# Altium schematic sheet UNO.SchDoc(UNO)
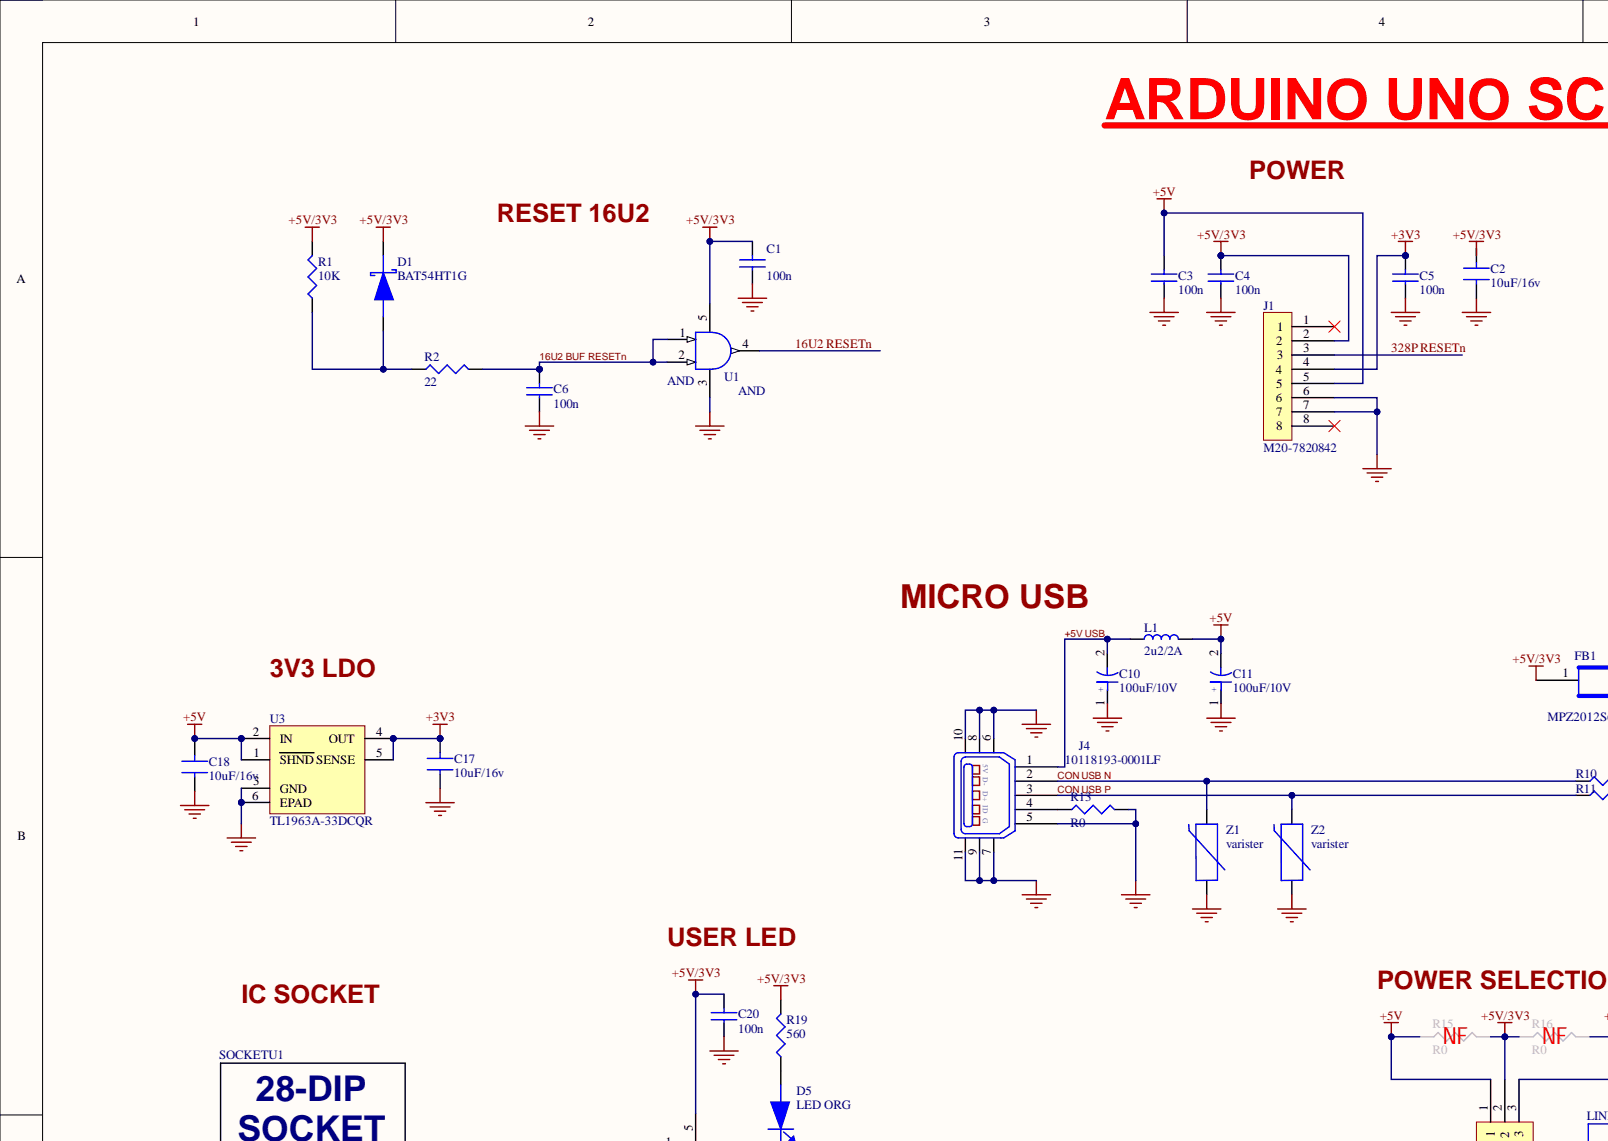
[diagram p0: UNO.SchDoc, top left]
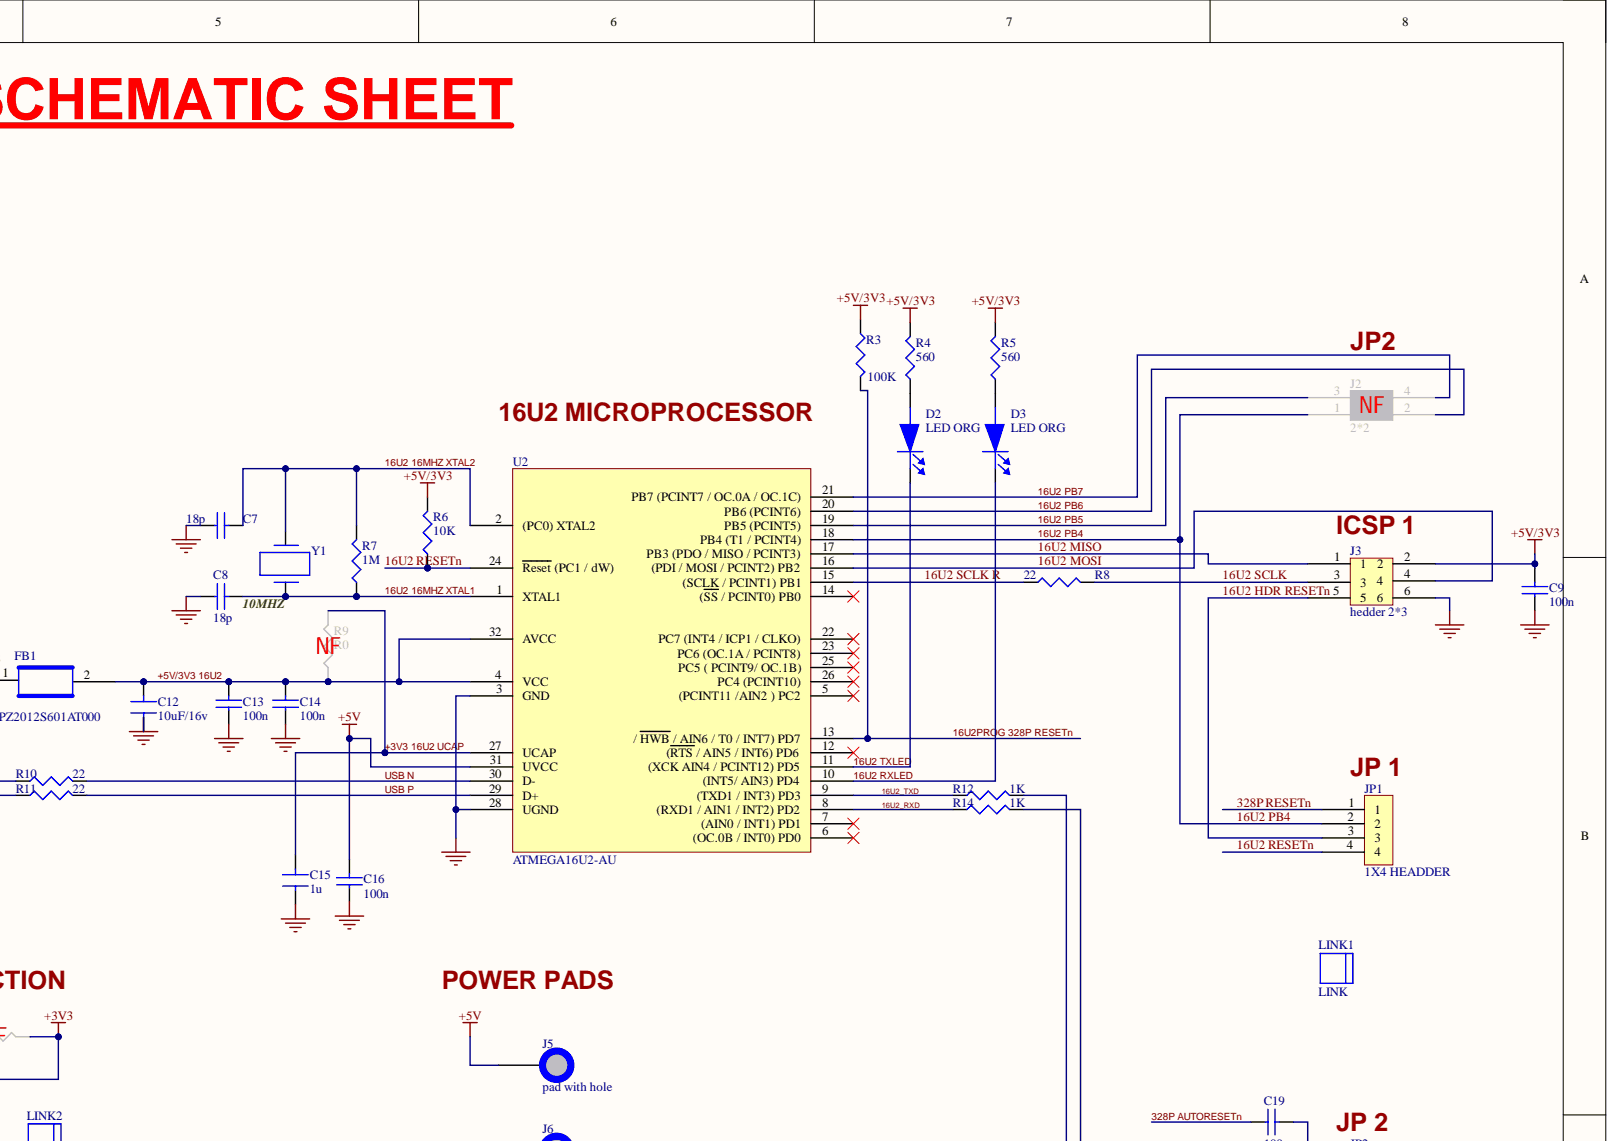
[diagram p1: UNO.SchDoc, top right]
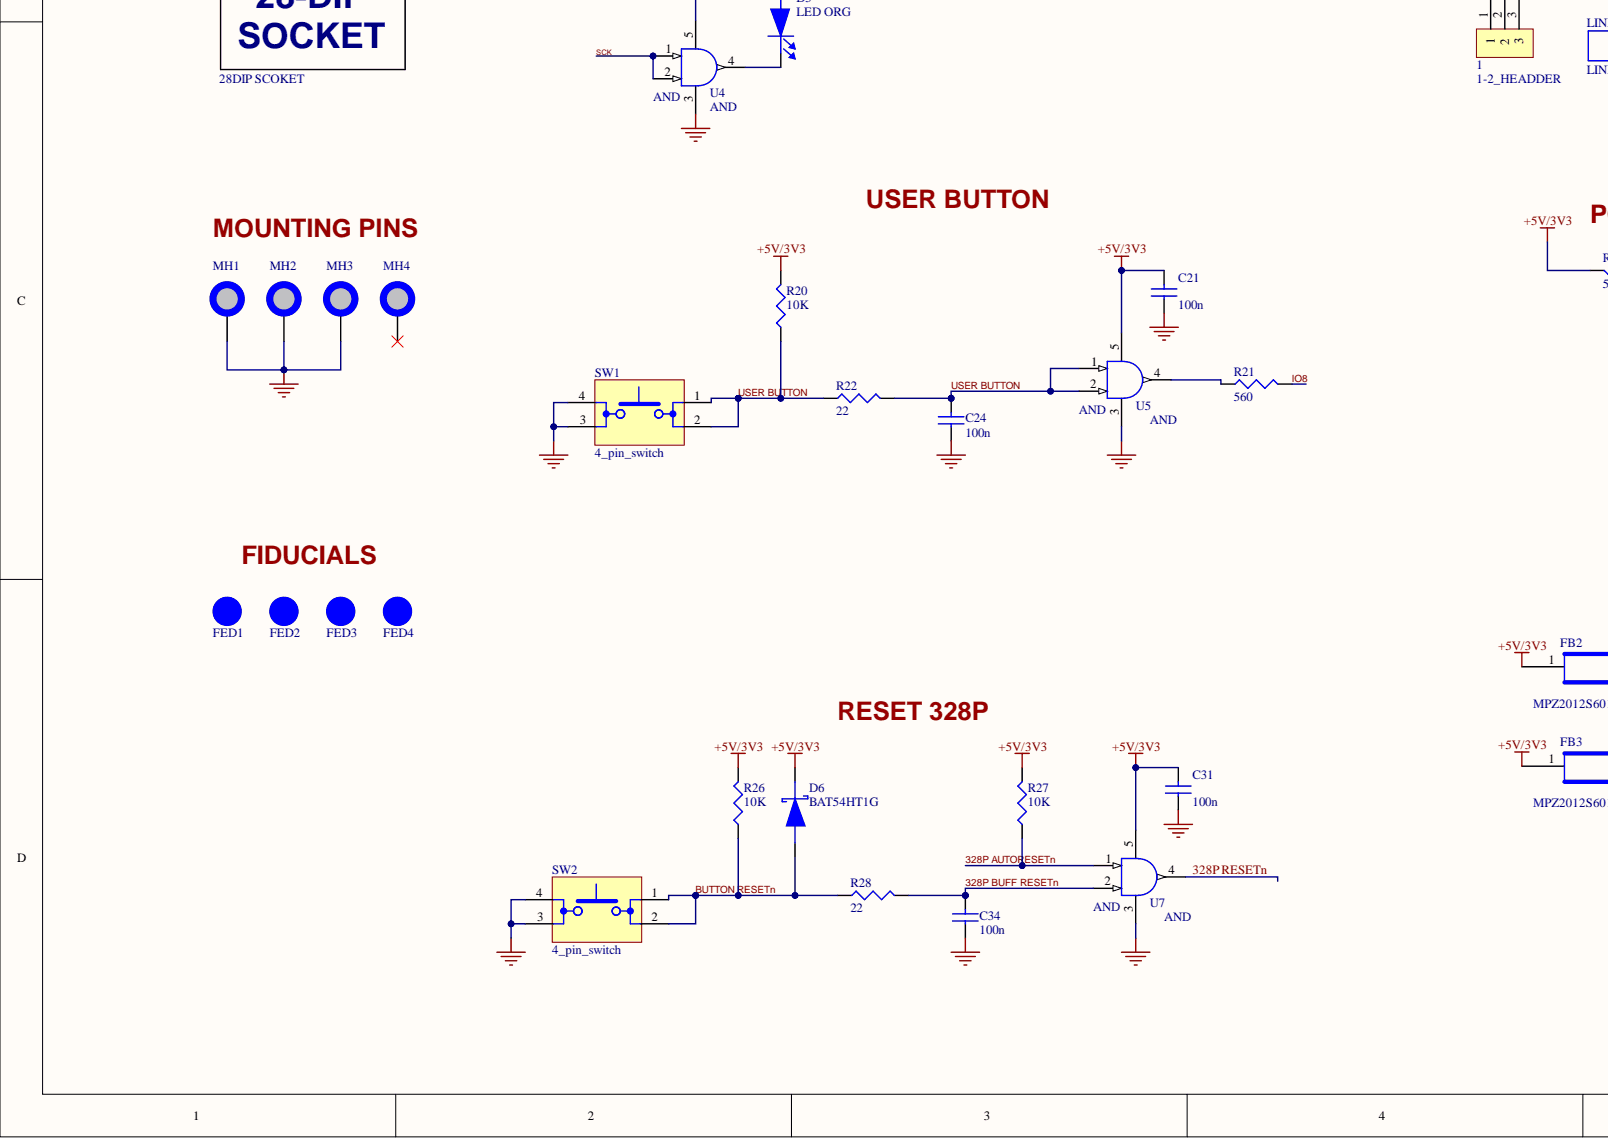
[diagram p2: UNO.SchDoc, bottom left]
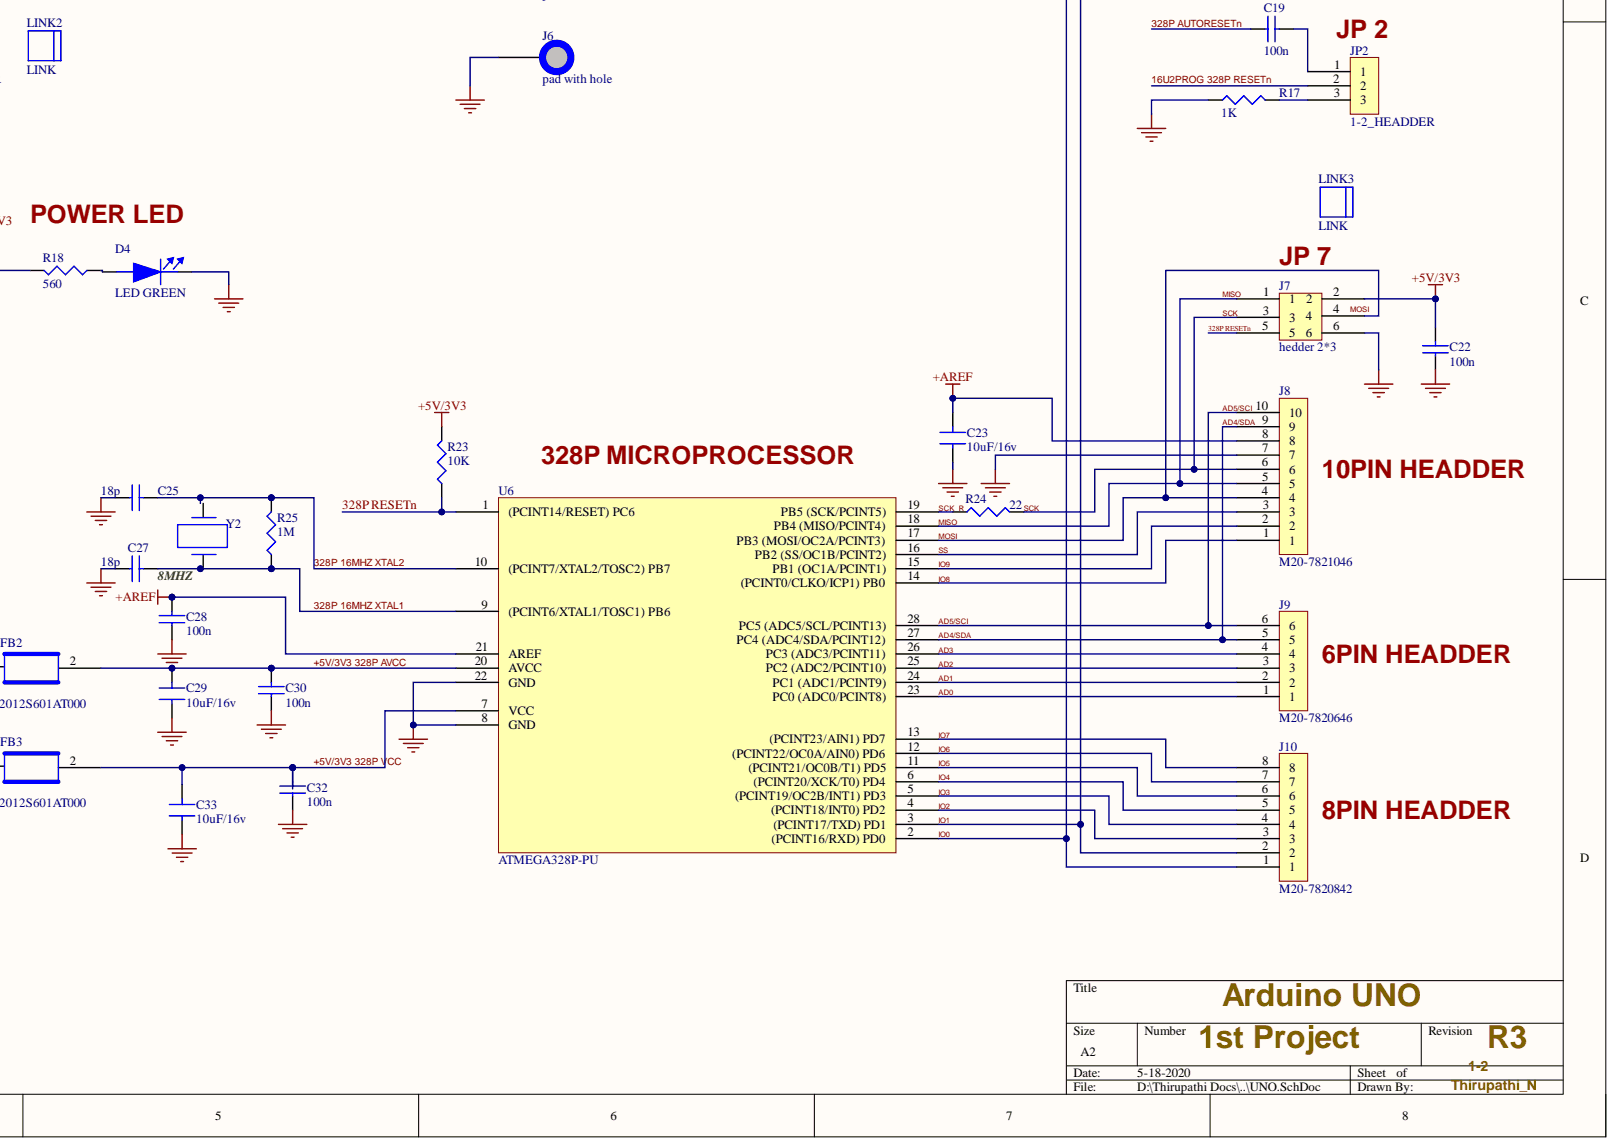
[diagram p3: UNO.SchDoc, bottom right]

## Parts
1  1-2_HEADDER  [hedder_female_3_pins - duplicate]
C1  100n  [C0805]
C2  10uF/16v  [C0805]
C3  100n  [C0805]
C4  100n  [C0805]
C5  100n  [C0805]
C6  100n  [C0805]
C7  18p  [C0805]
C8  18p  [C0805]
C9  100n  [C0805]
C10  100uF/10V  [C ALUMI]
C11  100uF/10V  [C ALUMI]
C12  10uF/16v  [C0805]
C13  100n  [C0805]
C14  100n  [C0805]
C15  1u  [C0805]
C16  100n  [C0805]
C17  10uF/16v  [C0805]
C18  10uF/16v  [C0805]
C19  100n  [C0805]
C20  100n  [C0805]
C21  100n  [C0805]
C22  100n  [C0805]
C23  10uF/16v  [C0805]
C24  100n  [C0805]
C25  18p  [C0805]
C27  18p  [C0805]
C28  100n  [C0805]
C29  10uF/16v  [C0805]
C30  100n  [C0805]
C31  100n  [C0805]
C32  100n  [C0805]
C33  10uF/16v  [C0805]
C34  100n  [C0805]
D1  BAT54HT1G  [diode]
D2  LED ORG  [LED ORG]
D3  LED ORG  [LED ORG]
D4  LED GREEN  [LED ORG]
D5  LED ORG  [LED ORG]
D6  BAT54HT1G  [diode]
FB1  MPZ2012S601AT000  [C0805]
FB2  MPZ2012S601AT000  [C0805]
FB3  MPZ2012S601AT000  [C0805]
FED1  FIDUCIAL 1MM  [FIDICIAL1]  (value not drawn)  (no BOM)
FED2  FIDUCIAL 1MM  [FIDICIAL1]  (value not drawn)  (no BOM)
FED3  FIDUCIAL 1MM  [FIDICIAL1]  (value not drawn)  (no BOM)
FED4  FIDUCIAL 1MM  [FIDICIAL1]  (value not drawn)  (no BOM)
J1  M20-7820842  [hedder_female_8_pins - duplicate]
J2  2*2  [hedder 2*2]
J3  hedder 2*3  [hedder 2*3]
J4  10118193-0001LF  [USB]
J5  pad with hole  [pad with hole]  (no BOM)
J6  pad with hole  [pad with hole]  (no BOM)
J7  hedder 2*3  [hedder 2*3]
J8  M20-7821046  [hedder_female]
J9  M20-7820646  [hedder_female_6_pins]
J10  M20-7820842  [hedder_female_8_pins - duplicate]
JP1  1X4 HEADDER  [hedder_female_4_pins]
JP2  1-2_HEADDER  [hedder_female_3_pins - duplicate]
L1  2u2/2A  [L2u2/2A]
LINK1  LINK  (mechanical)
LINK2  LINK  (mechanical)
LINK3  LINK  (mechanical)
MH1  Mount Holes  [MOUNTING HOLES]  (value not drawn)  (no BOM)
MH2  Mount Holes  [MOUNTING HOLES]  (value not drawn)  (no BOM)
MH3  Mount Holes  [MOUNTING HOLES]  (value not drawn)  (no BOM)
MH4  7.4 MOUNT  [MOUNTING7.4]  (value not drawn)  (no BOM)
R1  10K  [R0805]
R2  22  [R0805]
R3  100K  [R0805]
R4  560  [R0805]
R5  560  [R0805]
R6  10K  [R0805]
R7  1M  [R0805]
R8  22  [R0805]
R9  R0  [R0805]
R10  22  [R0805]
R11  22  [R0805]
R12  1K  [R0805]
R13  R0  [R0805]
R14  1K  [R0805]
R15  R0  [R0805]
R16  R0  [R0805]
R17  1K  [R0805]
R18  560  [R0805]
R19  560  [R0805]
R20  10K  [R0805]
R21  560  [R0805]
R22  22  [R0805]
R23  10K  [R0805]
R24  22  [R0805]
R25  1M  [R0805]
R26  10K  [R0805]
R27  10K  [R0805]
R28  22  [R0805]
SOCKETU1  28DIP SCOKET  [SOCKET]
SW1  4_pin_switch  [4pin_switch]
SW2  4_pin_switch  [4pin_switch]
U1  AND  [AND]
U2  ATMEGA16U2-AU  [TQFP 32]
U3  TL1963A-33DCQR  [SOP10]
U4  AND  [AND]
U5  AND  [AND]
U6  ATMEGA328P-PU  [U?]
U7  AND  [AND]
Y1
Y1  10MHZ  [Crystal]
Y2
Y2  8MHZ  [Crystal]
Z1  varister  [R0603]
Z2  varister  [R0603]

## Nets
+3V3: 1.3 C5.2 C17.2 J1.4 R16.2 U3.4(OUT) U3.5(SENSE)
+5V: 1.1 C3.2 C11.2 C16.2 C18.2 J1.5 J5.1 L1.2 R15.1 U2.31(UVCC) U3.1(SHND) U3.2(IN)
+5V/3V3: 1.2 C1.2 C4.2 C9.2 C20.2 C21.2 C22.2 C31.2 D1.1 D6.1 FB1.1 FB2.1 FB3.1 J1.2 J3.2 J7.2 R1.2 R3.1 R4.2 R5.2 R6.2 R15.2 R16.1 R18.2 R19.2 R20.2 R23.2 R26.2 R27.2 U1.5 U4.5 U5.5 U7.5
+AREF: C23.2 C28.2 J8.8 U6.21(AREF)
GND: C1.1 C2.1 C3.1 C4.1 C5.1 C6.1 C7.1 C8.1 C9.1 C10.1 C11.1 C12.1 C13.1 C14.1 C15.1 C16.1 C17.1 C18.1 C20.1 C21.1 C22.1 C23.1 C24.1 C25.1 C27.1 C28.1 C29.1 C30.1 C31.1 C32.1 C33.1 C34.1 D4.1 J1.6 J1.7 J3.6 J4.5 J4.6 J4.7 J4.8 J4.9 J4.10 J4.11 J6.1 J7.6 J8.7 MH1.1 MH2.1 MH3.1 R13.2 R17.1 SW1.3 SW1.4 SW2.3 SW2.4 U1.3 U2.3(GND) U2.28(UGND) U3.3(GND) U3.6(EPAD) U4.3 U5.3 U6.8(GND) U6.22(GND) U7.3 Z1.1 Z2.1
16U2 16MHZ XTAL1: C8.2 R7.1 U2.1(XTAL1) Y1.1
16U2 16MHZ XTAL2: C7.2 R7.2 U2.2((PC0)_XTAL2) Y1.2
16U2 BUF RESETn: C6.2 R2.2 U1.1 U1.2
16U2 HDR RESETn: J3.5 JP1.3
16U2 MISO: J3.1 U2.17(PB3_(PDO_/_MISO_/_PCINT3))
16U2 MOSI: J3.4 U2.16((PDI_/_MOSI_/_PCINT2)_PB2)
16U2 PB4: J2.1(NF) JP1.2 U2.18(PB4_(T1_/_PCINT4))
16U2 PB5: J2.3 U2.19(PB5_(PCINT5))
16U2 PB6: J2.2 U2.20(PB6_(PCINT6))
16U2 PB7: J2.4 U2.21(PB7_(PCINT7_/_OC.0A_/_OC.1C))
16U2 RESETn: JP1.4 R6.1 U1.4 U2.24(Reset_(PC1_/_dW))
16U2 RXLED: D3.1 U2.10((INT5/_AIN3)_PD4)
16U2 SCLK: J3.3 R8.2
16U2 SCLK R: R8.1 U2.15((SCLK_/_PCINT1)_PB1)
16U2 TXLED: D2.1 U2.11((XCK_AIN4_/_PCINT12)_PD5)
16U2_RXD: R14.1 U2.8((RXD1_/_AIN1_/_INT2)_PD2)
16U2_TXD: R12.1 U2.9((TXD1_/_INT3)_PD3)
16U2PROG 328P RESETn: JP2.2 R3.2 U2.13(/_HWB_/_AIN6_/_T0_/_INT7)_PD7)
328P 16MHZ XTAL1: C27.2 R25.1 U6.9((PCINT6/XTAL1/TOSC1)_PB6) Y2.1
328P 16MHZ XTAL2: C25.2 R25.2 U6.10((PCINT7/XTAL2/TOSC2)_PB7) Y2.2
328P AUTORESETn: C19.1 R27.1 U7.1
328P BUFF RESETn: C34.2 R28.2 U7.2
328P RESETn: J1.3 J7.5 JP1.1 R23.1 U6.1((PCINT14/RESET)_PC6) U7.4
+3V3 16U2 UCAP: C15.2 R9.2 U2.27(UCAP)
+5V USB: C10.2 J4.1 L1.1
+5V/3V3 16U2: C12.2 C13.2 C14.2 FB1.2 R9.1 U2.4(VCC) U2.32(AVCC)
+5V/3V3 328P AVCC: C29.2 C30.2 FB2.2 U6.20(AVCC)
+5V/3V3 328P VCC: C32.2 C33.2 FB3.2 U6.7(VCC)
AD0: J9.1 U6.23(PC0_(ADC0/PCINT8))
AD1: J9.2 U6.24(PC1_(ADC1/PCINT9))
AD2: J9.3 U6.25(PC2_(ADC2/PCINT10))
AD3: J9.4 U6.26(PC3_(ADC3/PCINT11))
AD4/SDA: J8.9 J9.5 U6.27(PC4_(ADC4/SDA/PCINT12))
AD5/SCI: J8.10 J9.6 U6.28(PC5_(ADC5/SCL/PCINT13))
BUTTON RESETn: D6.2 R26.1 R28.1 SW2.1 SW2.2
CON USB N: J4.2 R10.1 Z1.2
CON USB P: J4.3 R11.1 Z2.2
IO0: J10.1 R12.2 U6.2((PCINT16/RXD)_PD0)
IO1: J10.2 R14.2 U6.3((PCINT17/TXD)_PD1)
IO2: J10.3 U6.4((PCINT18/INT0)_PD2)
IO3: J10.4 U6.5((PCINT19/OC2B/INT1)_PD3)
IO4: J10.5 U6.6((PCINT20/XCK/T0)_PD4)
IO5: J10.6 U6.11((PCINT21/OC0B/T1)_PD5)
IO6: J10.7 U6.12((PCINT22/OC0A/AIN0)_PD6)
IO7: J10.8 U6.13((PCINT23/AIN1)_PD7)
IO8: J8.1 R21.2 U6.14((PCINT0/CLKO/ICP1)_PB0)
IO9: J8.2 U6.15(PB1_(OC1A/PCINT1))
MISO: J7.1 J8.5 U6.18(PB4_(MISO/PCINT4))
MOSI: J7.4 J8.4 U6.17(PB3_(MOSI/OC2A/PCINT3))
SCK: J7.3 J8.6 R24.2 U4.1 U4.2
SCK_R: R24.1 U6.19(PB5_(SCK/PCINT5))
SS: J8.3 U6.16(PB2_(SS/OC1B/PCINT2))
USB N: R10.2 U2.30(D-)
USB P: R11.2 U2.29(D+)
USER BUTTON: C24.2 R20.1 R22.1 R22.2 SW1.1 SW1.2 U5.1 U5.2
NetC19_2: C19.2 JP2.1
NetD1_2: D1.2 R1.1 R2.1
NetD2_2: D2.2 R4.1
NetD3_2: D3.2 R5.1
NetD4_2: D4.2 R18.1
NetD5_1: D5.1 U4.4
NetD5_2: D5.2 R19.1
NetJ4_4: J4.4 R13.1
NetJP2_3: JP2.3 R17.2
NetR21_1: R21.1 U5.4

Not connected: C2 2; J1 1 8; MH4 1; U2 5((PCINT11_/AIN2_)_PC2) 6((OC.0B_/_INT0)_PD0) 7((AIN0_/_INT1)_PD1) 12((RTS_/_AIN5_/_INT6)_PD6) 14((SS_/_PCINT0)_PB0) 22(PC7_(INT4_/_ICP1_/_CLKO)) 23(PC6_(OC.1A_/_PCINT8)) 25(PC5_(_PCINT9/_OC.1B)) 26(PC4_(PCINT10))
